# Altium schematic sheet AMU-S29-V00-PDOCShutdownRelay.SchDoc
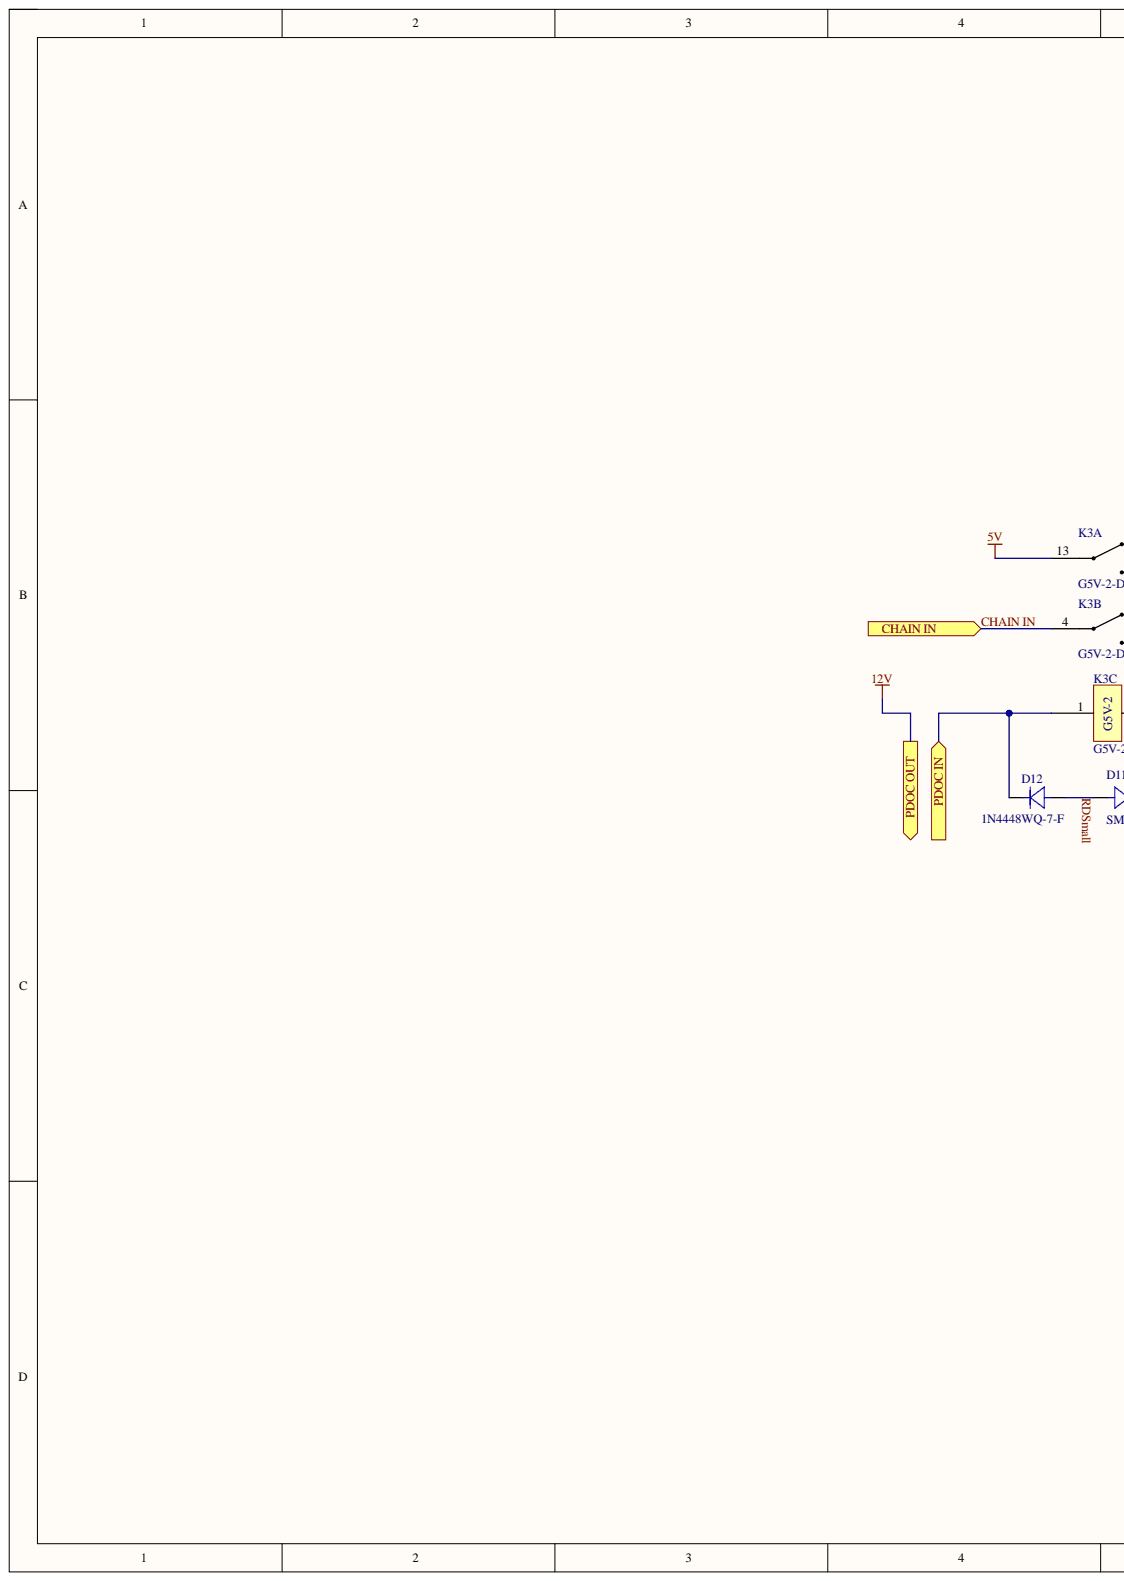
[diagram p0: AMU-S29-V00-PDOCShutdownRelay.SchDoc, left]
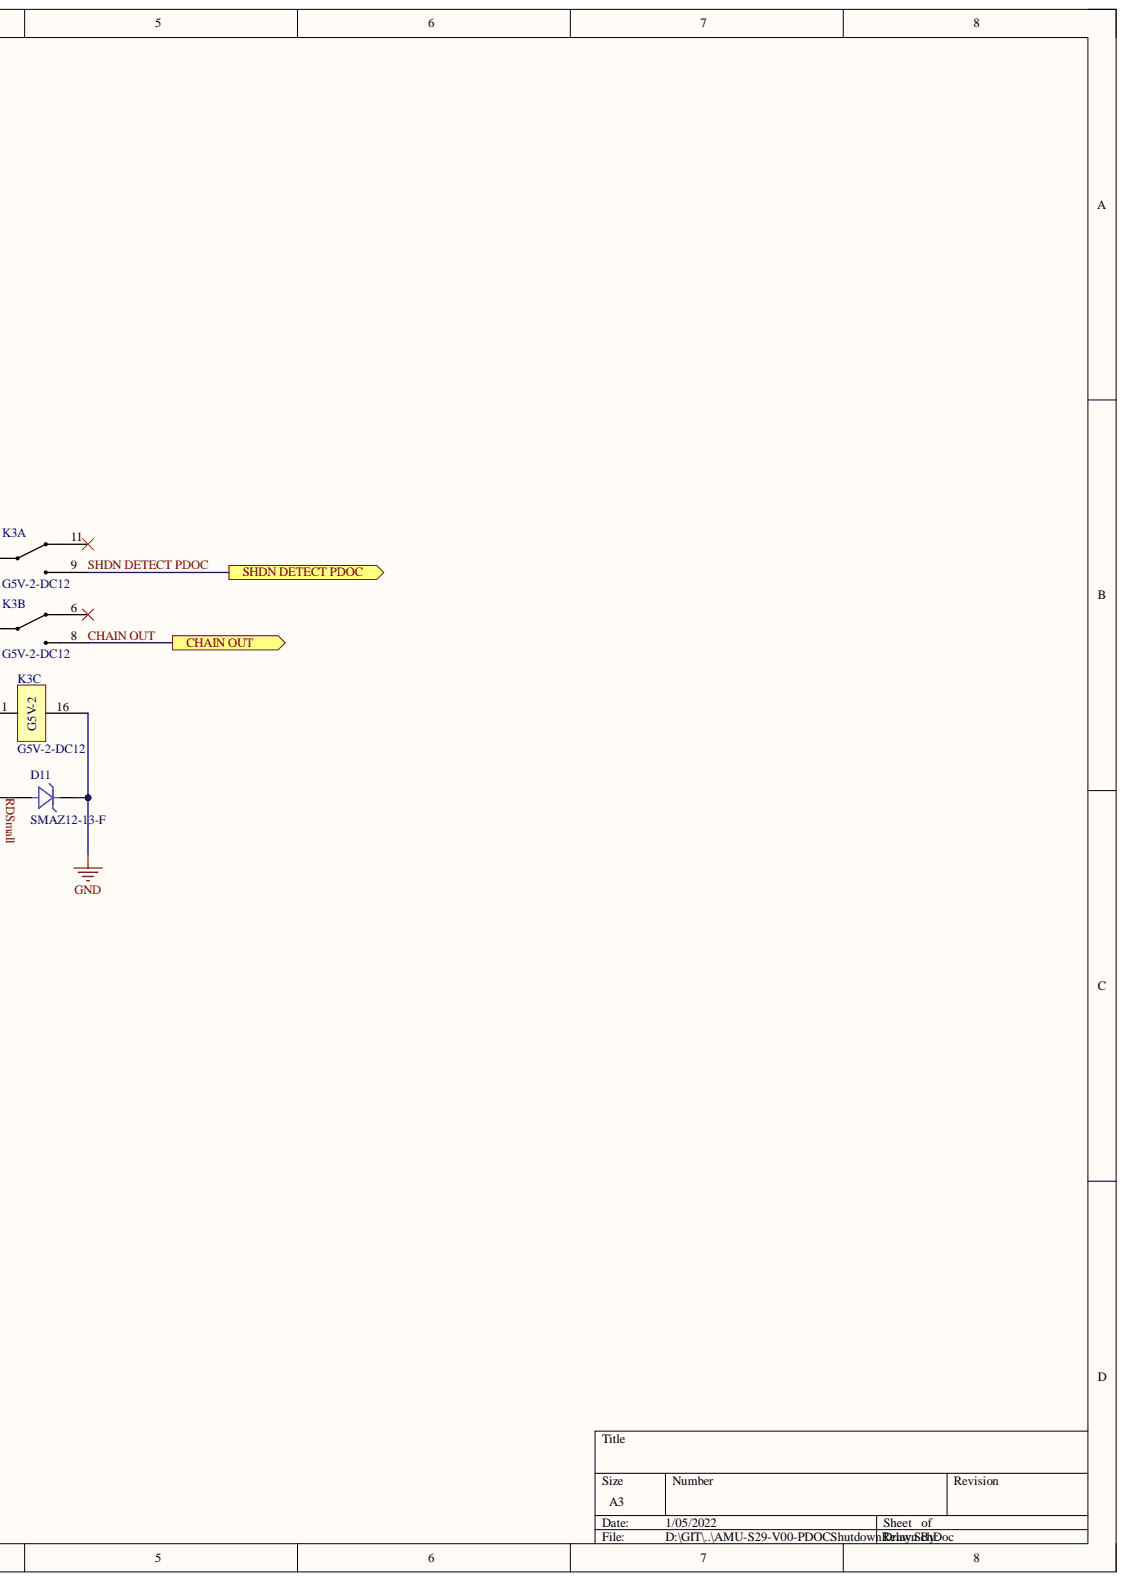
[diagram p1: AMU-S29-V00-PDOCShutdownRelay.SchDoc, right]

## Parts
D11  SMAZ12-13-F  [SMA]
D12  1N4448WQ-7-F  [SMA]
K3  G5V-2-DC12  [G5V-2]  (parts A,B,C)

## Ports
CHAIN IN, CHAIN OUT, PDOC IN, PDOC OUT, SHDN DETECT PDOC

## Nets
5V: K3.13
GND: D11.K K3.16
CHAIN IN: K3.4 ; port CHAIN IN
CHAIN OUT: K3.8 ; port CHAIN OUT
PDOC IN: D12.K K3.1 ; port PDOC IN
RDSmall: D11.A D12.A
SHDN DETECT PDOC: K3.9 ; port SHDN DETECT PDOC
12V: ; port PDOC OUT

Not connected: K3 6 11

## Library data of the parts
D11 = SMAZ12-13-F: Zener Diode 12V 1W ±5% Surface Mount SMA; Manufacturer: ON Semiconductor; MPN: MM3Z56VB; Component Kind: Standard; ComponentLink1Description: Digikey; ComponentLink1URL: https://www.digikey.com.au/product-detail/en/diodes-incorporated/SMAZ12-13-F/SMAZ12-FDICT-ND/725073; ComponentLink2Description: Datasheet; ComponentLink2URL: https://www.diodes.com/assets/Datasheets/ds18015.pdf; Library Name: QUTMS_DiodeLibrary.SchLib
D12 = 1N4448WQ-7-F: Diode, SMD, 75V, 5%, 250mA, SOD123; Manufacturer: ON Semiconductor / Fairchild; Manufacturer Part Number: 1N914BWS; Average Rectified Current: 150mA; Element Configuration: Single; Forward Voltage: 1V; Max Forward Surge Current (Ifsm): 4A; Max Reverse Leakage Current: 5uA; Power Dissipation: 200mW; Recovery Time: 4ns; Category: Rectifier Diodes; Component Kind: Standard; Contact Plating: Tin,Matte; Forward Current: 150mA; Height: 1mm; Lead Free: Lead Free; Length: 1.8mm; Max Operating Temperature: 150°C; Max Repetitive Reverse Voltage (Vrrm): 75V; Max Reverse Voltage (DC): 75V; Min Operating Temperature: -55°C; Mount: Surface Mount; Number of Pins: 2; Package Quantity: 1; Packaging: Tape and Reel; Peak Reverse Current: 5uA; Radiation Hardening: No; REACH SVHC: No SVHC; Reverse Recovery Time: 4ns; Reverse Voltage: 75V; Weight: 0.0276g; Width: 1.35mm; Library Name: QUTMS_DiodeLibrary.SchLib
K3 = G5V-2-DC12: General Purpose Relay DPDT (2 Form C) Through Hole, 12V, 2A; Component Kind: Standard; Library Name: QUTMS_RelayLibrary.SchLib
